# Altium schematic sheet wechat_iotkit_TAG_BB.SchDoc
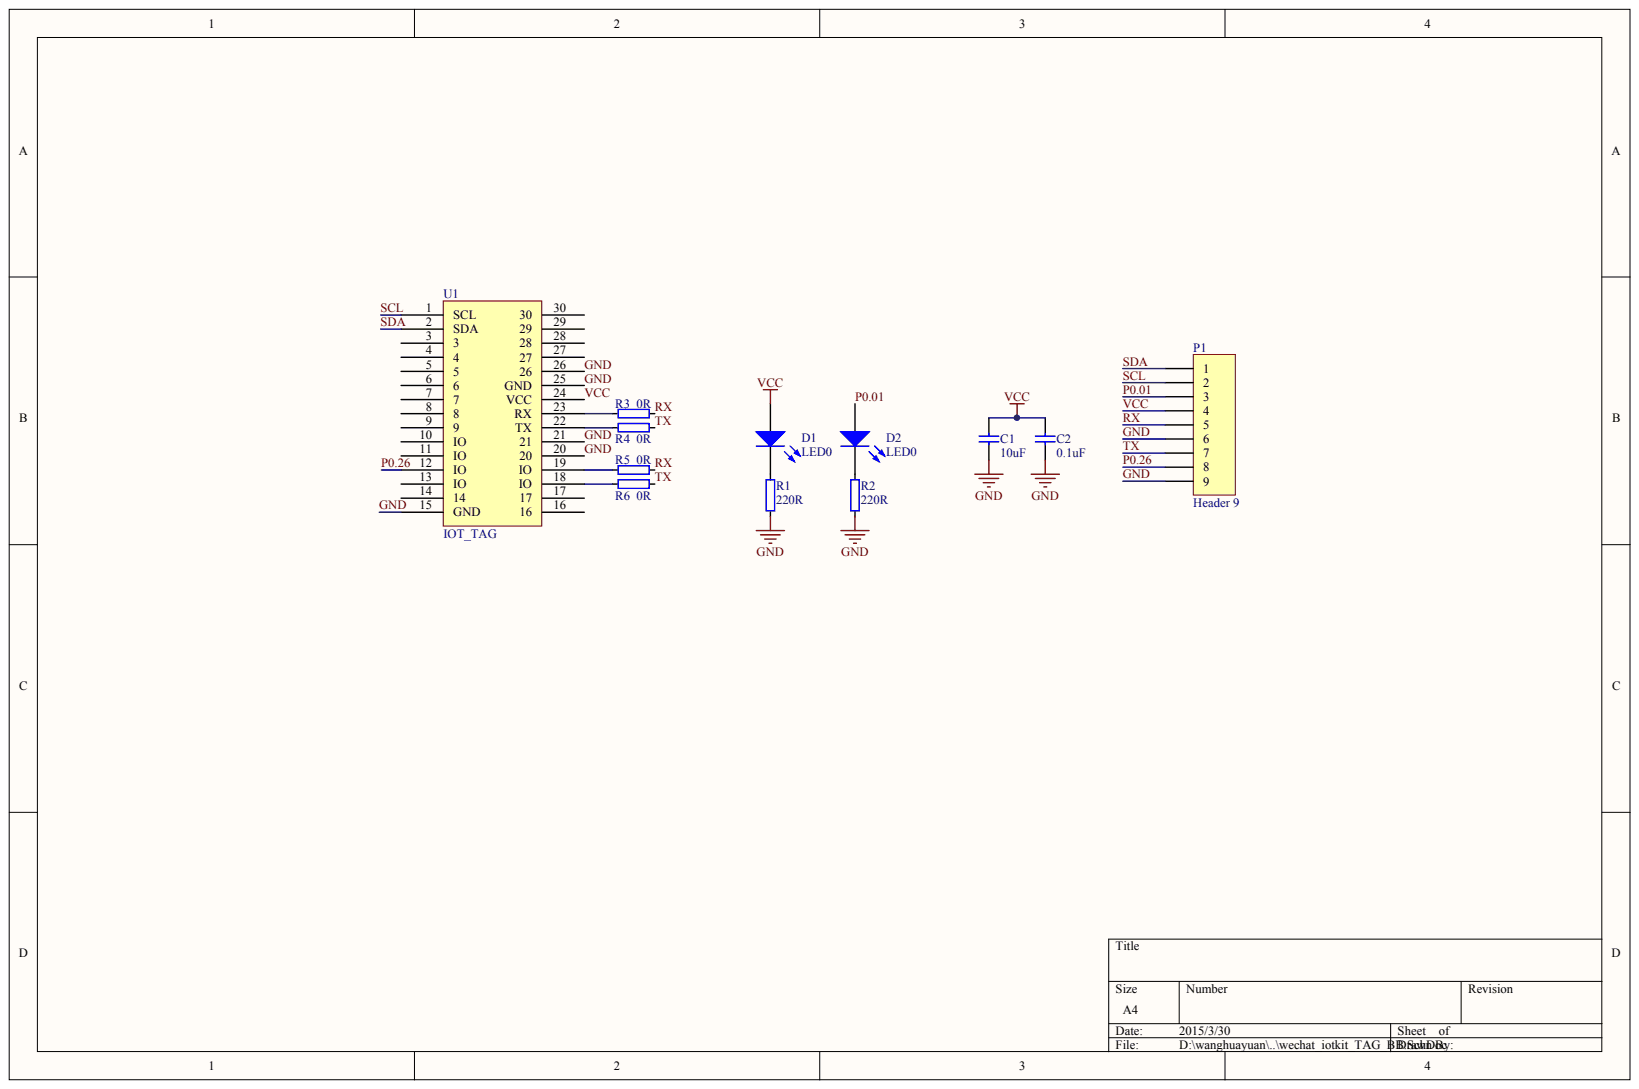
[diagram p0: wechat_iotkit_TAG_BB.SchDoc]

## Parts
C1  10uF
C2  0.1uF
D1  LED0
D2  LED0
P1  Header 9
R1  220R  [RES0603]
R2  220R  [RES0603]
R3  0R  [0603]
R4  0R  [0603]
R5  0R  [0603]
R6  0R  [0603]
U1  IOT_TAG

## Nets
GND: C1.1 C2.1 P1.6 P1.9 R1.1 R2.1 U1.15(GND) U1.20 U1.21 U1.25(GND) U1.26
P0.01: D2.1 P1.3
P0.26: P1.8 U1.12(IO)
RX: P1.5 R3.2 R5.2
SCL: P1.2 U1.1(SCL)
SDA: P1.1 U1.2(SDA)
TX: P1.7 R4.2 R6.2
VCC: C1.2 C2.2 D1.1 P1.4 U1.24(VCC)
NetD1_2: D1.2 R1.2
NetD2_2: D2.2 R2.2
NetR3_1: R3.1 U1.23(RX)
NetR4_1: R4.1 U1.22(TX)
NetR5_1: R5.1 U1.19(IO)
NetR6_1: R6.1 U1.18(IO)

Not connected: U1 3 4 5 6 7 8 9 10(IO) 11(IO) 13(IO) 14 16 17 27 28 29 30

## Library data of the parts
C1 C2 D1 D2 P1 R1-R6 U1 = (no symbol name)
  C1: Value: 10uF; Footprint Doc: 0603
  C2: Value: 0.1uF; Footprint Doc: 0603
  D1 D2: Published: 8-Jun-2000; LatestRevisionDate: 08-Jul-2005; LatestRevisionNote: Mech Layer 1 removed.; PackageReference: LED-0; PackageDescription: LED; 2 Leads
  P1: LatestRevisionDate: 17-Jul-2002; LatestRevisionNote: Re-released for DXP Platform.
  R1 R2: Value: 220R
  R3-R6: Value: 0R
  D1 D2 P1: Publisher: Altium Limited
